# Altium schematic sheet Xface Conn.SCHDOC("Xface Conn")
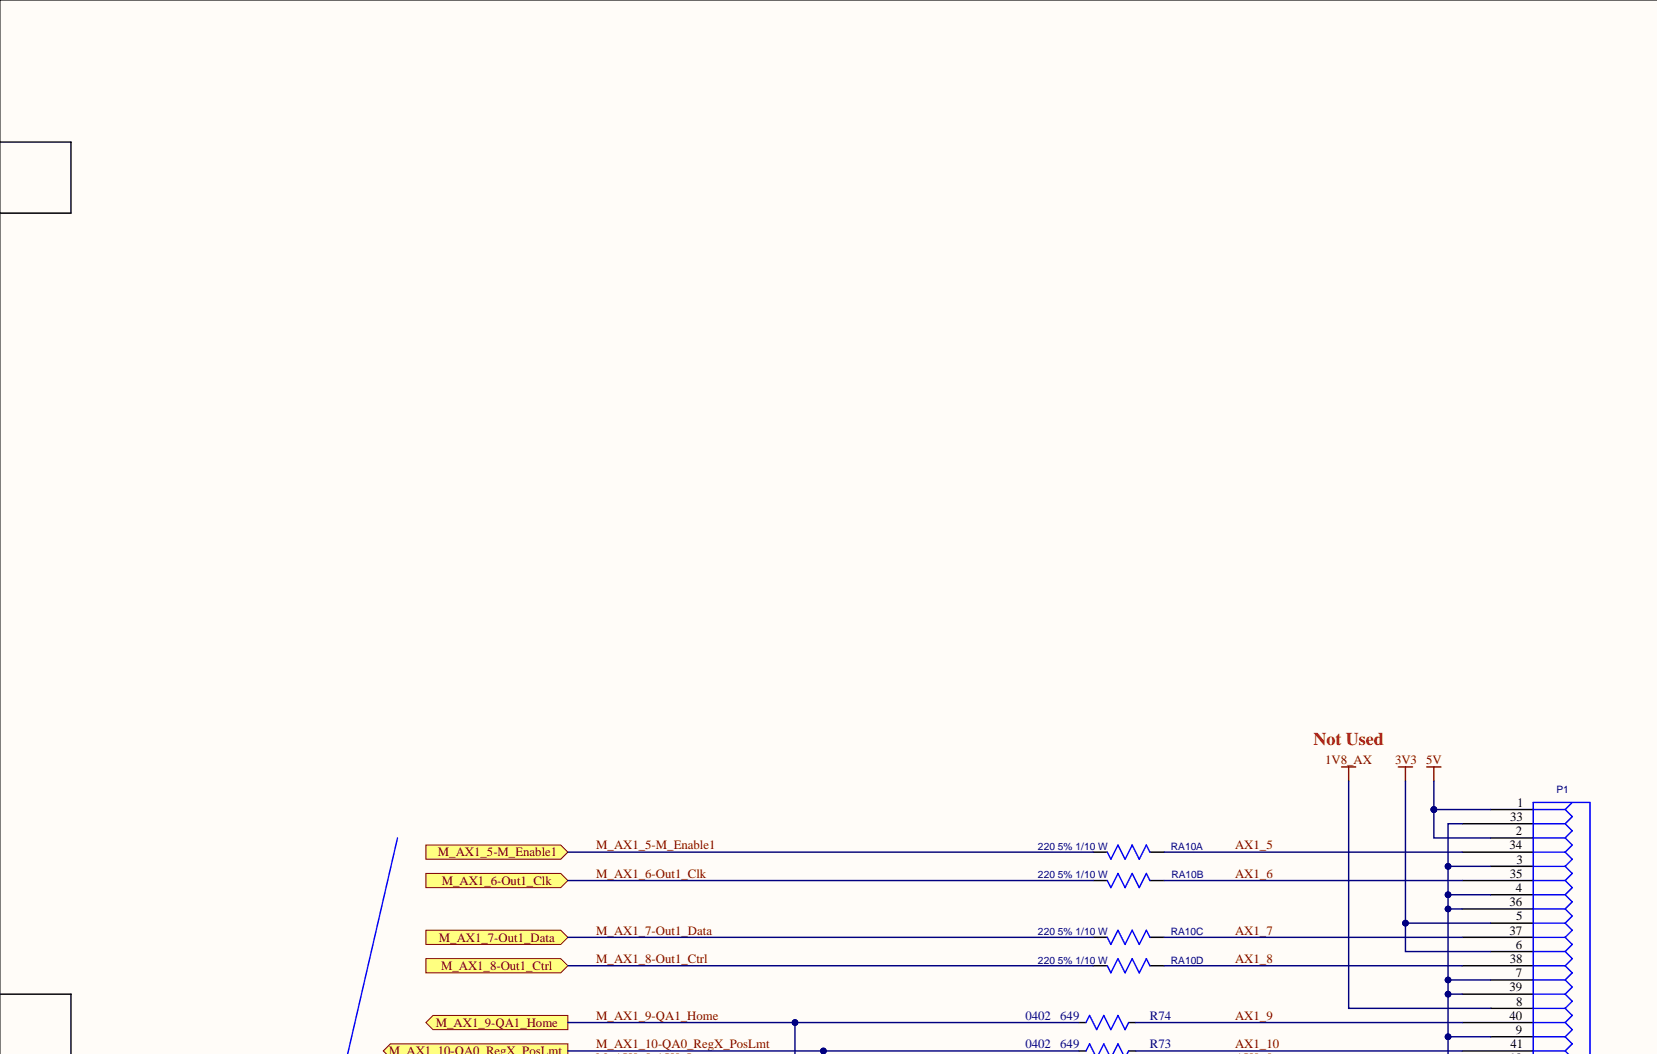
[diagram p0: Xface Conn.SCHDOC, top left]
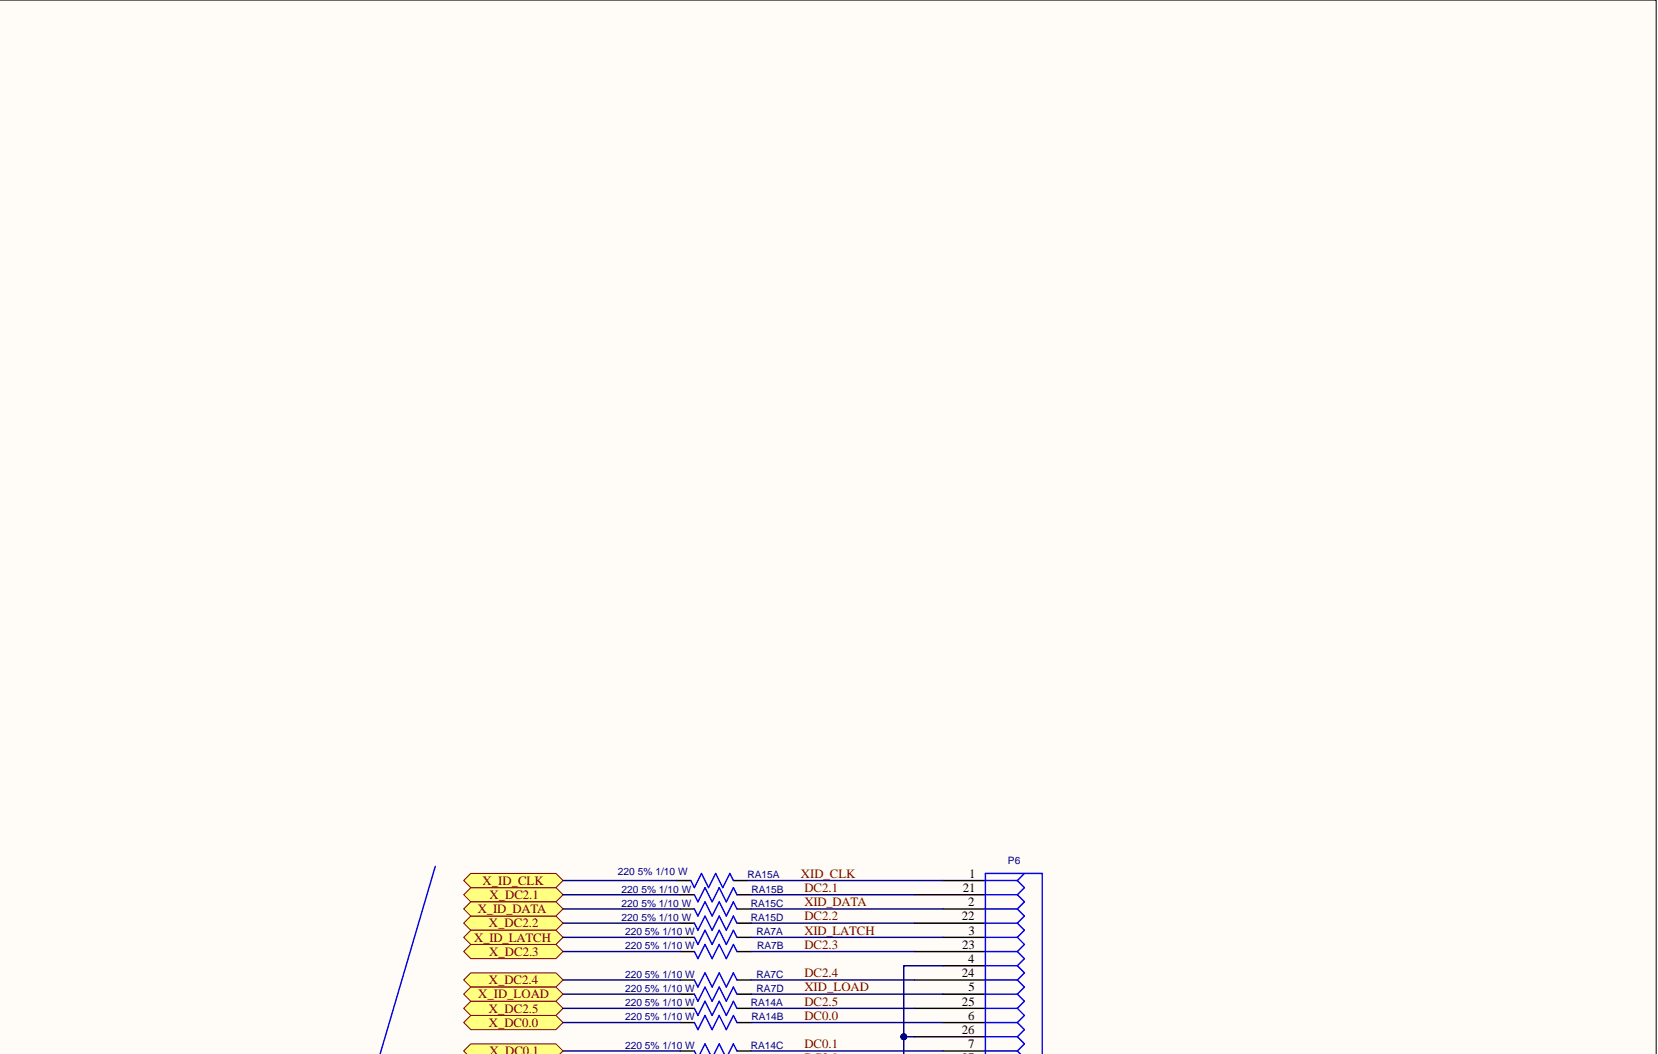
[diagram p1: Xface Conn.SCHDOC, top right]
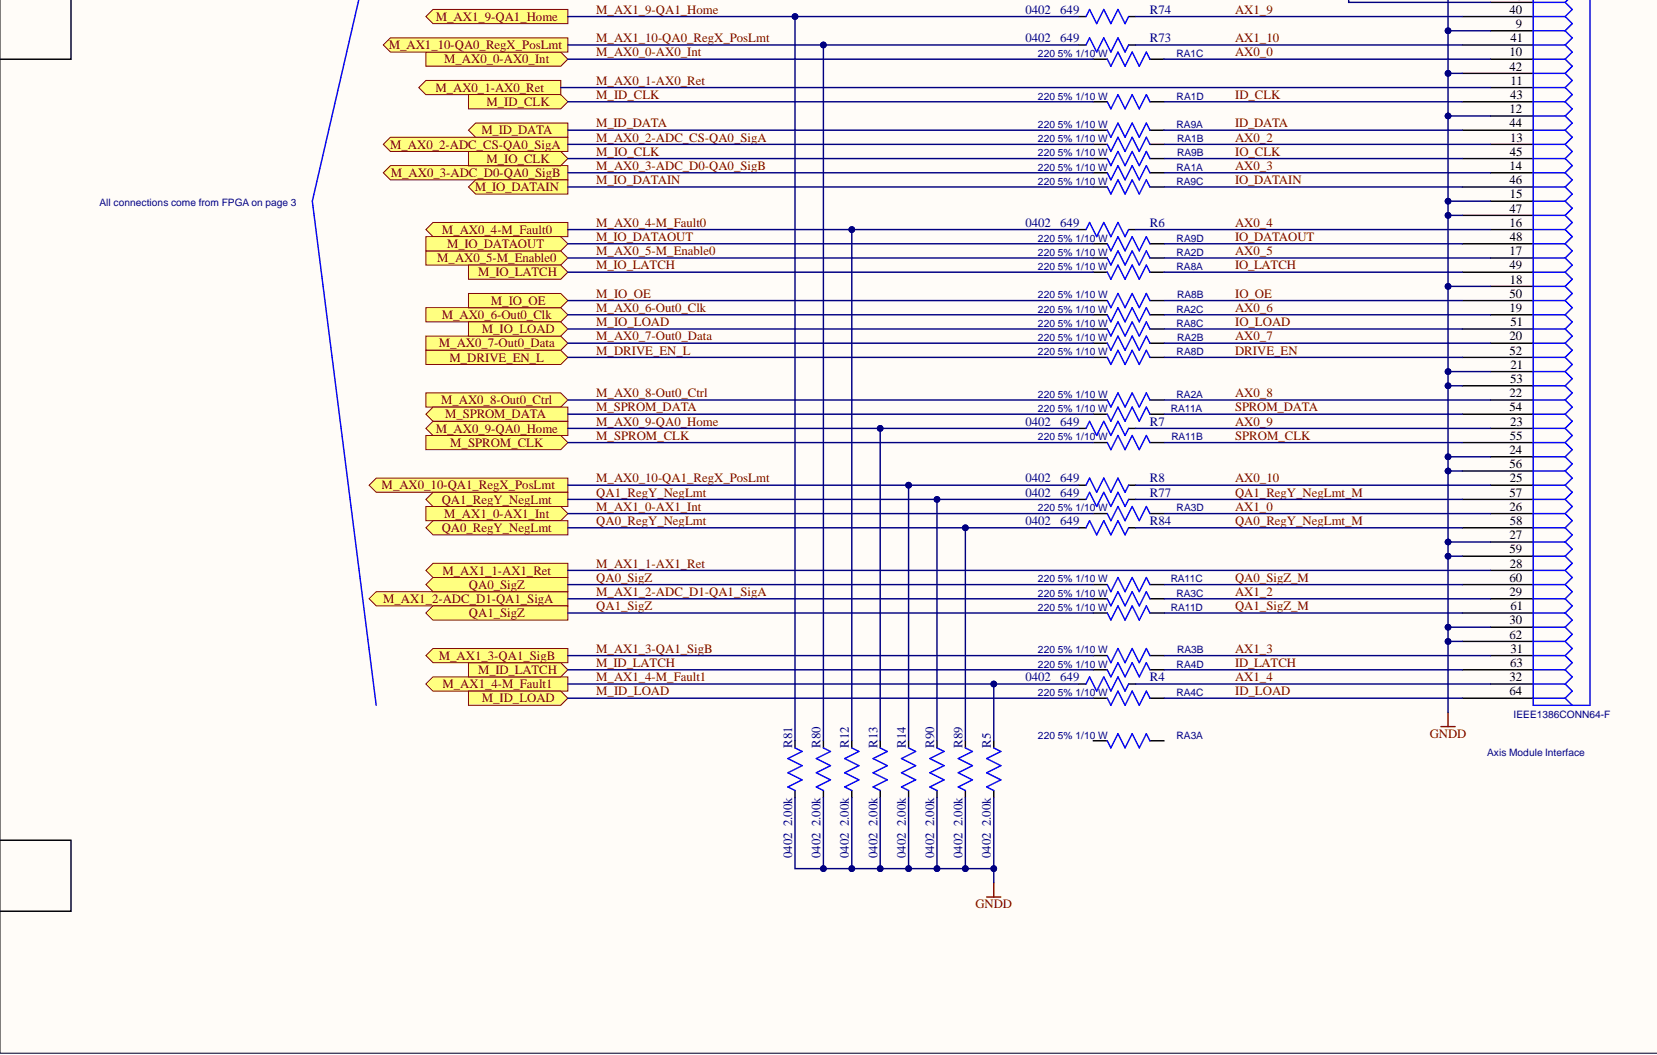
[diagram p2: Xface Conn.SCHDOC, bottom left]
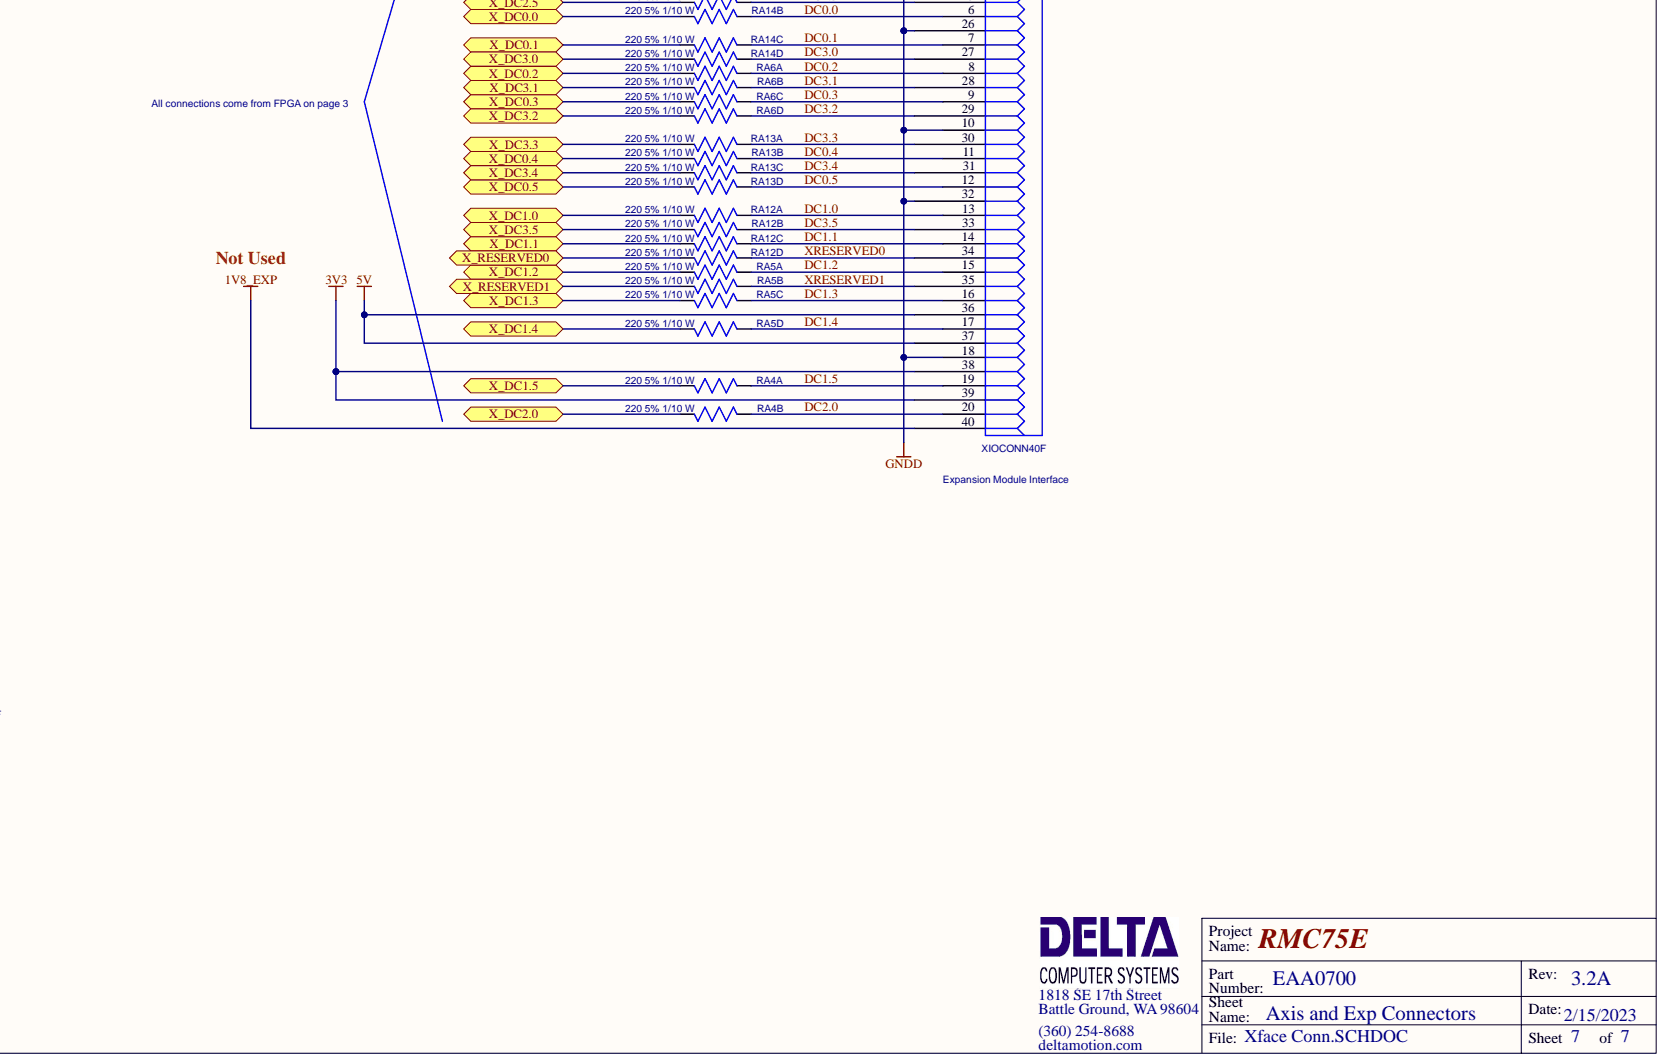
[diagram p3: Xface Conn.SCHDOC, bottom right]

## Parts
P1  IEEE1386CONN64-F  [MEZZCONNIEEE1386-64]
P6  XIOCONN40F  [XIOCONN40F]
R4  649  CaseSize: 0402  [RESC1005-N [0402]]
R5  2.00k  CaseSize: 0402  [RESC1005-N [0402]]
R6  649  CaseSize: 0402  [RESC1005-N [0402]]
R7  649  CaseSize: 0402  [RESC1005-N [0402]]
R8  649  CaseSize: 0402  [RESC1005-N [0402]]
R12  2.00k  CaseSize: 0402  [RESC1005-N [0402]]
R13  2.00k  CaseSize: 0402  [RESC1005-N [0402]]
R14  2.00k  CaseSize: 0402  [RESC1005-N [0402]]
R73  649  CaseSize: 0402  [RESC1005-N [0402]]
R74  649  CaseSize: 0402  [RESC1005-N [0402]]
R77  649  CaseSize: 0402  [RESC1005-N [0402]]
R80  2.00k  CaseSize: 0402  [RESC1005-N [0402]]
R81  2.00k  CaseSize: 0402  [RESC1005-N [0402]]
R84  649  CaseSize: 0402  [RESC1005-N [0402]]
R89  2.00k  CaseSize: 0402  [RESC1005-N [0402]]
R90  2.00k  CaseSize: 0402  [RESC1005-N [0402]]
RA1  220 5% 1/10 W  [RES ARRAY 742]  (parts A,B,C,D)
RA2  220 5% 1/10 W  [RES ARRAY 742]  (parts A,B,C,D)
RA3  220 5% 1/10 W  [RES ARRAY 742]  (parts A,B,C,D)
RA4  220 5% 1/10 W  [RES ARRAY 742]  (parts A,B,C,D)
RA5  220 5% 1/10 W  [RES ARRAY 742]  (parts A,B,C,D)
RA6  220 5% 1/10 W  [RES ARRAY 742]  (parts A,B,C,D)
RA7  220 5% 1/10 W  [RES ARRAY 742]  (parts A,B,C,D)
RA8  220 5% 1/10 W  [RES ARRAY 742]  (parts A,B,C,D)
RA9  220 5% 1/10 W  [RES ARRAY 742]  (parts A,B,C,D)
RA10  220 5% 1/10 W  [RES ARRAY 742]  (parts A,B,C,D)
RA11  220 5% 1/10 W  [RES ARRAY 742]  (parts A,B,C,D)
RA12  220 5% 1/10 W  [RES ARRAY 742]  (parts A,B,C,D)
RA13  220 5% 1/10 W  [RES ARRAY 742]  (parts A,B,C,D)
RA14  220 5% 1/10 W  [RES ARRAY 742]  (parts A,B,C,D)
RA15  220 5% 1/10 W  [RES ARRAY 742]  (parts A,B,C,D)

## Ports
M_AX0_0-AX0_Int, M_AX0_1-AX0_Ret, M_AX0_2-ADC_CS-QA0_SigA, M_AX0_3-ADC_D0-QA0_SigB, M_AX0_4-M_Fault0, M_AX0_5-M_Enable0, M_AX0_6-Out0_Clk, M_AX0_7-Out0_Data, M_AX0_8-Out0_Ctrl, M_AX0_9-QA0_Home, M_AX0_10-QA1_RegX_PosLmt, M_AX1_0-AX1_Int, M_AX1_1-AX1_Ret, M_AX1_2-ADC_D1-QA1_SigA, M_AX1_3-QA1_SigB, M_AX1_4-M_Fault1, M_AX1_5-M_Enable1, M_AX1_6-Out1_Clk, M_AX1_7-Out1_Data, M_AX1_8-Out1_Ctrl, M_AX1_9-QA1_Home, M_AX1_10-QA0_RegX_PosLmt, M_DRIVE_EN_L, M_ID_CLK, M_ID_DATA, M_ID_LATCH, M_ID_LOAD, M_IO_CLK, M_IO_DATAIN, M_IO_DATAOUT, M_IO_LATCH, M_IO_LOAD, M_IO_OE, M_SPROM_CLK, M_SPROM_DATA, QA0_RegY_NegLmt, QA0_SigZ, QA1_RegY_NegLmt, QA1_SigZ, X_DC0.0, X_DC0.1, X_DC0.2, X_DC0.3, X_DC0.4, X_DC0.5, X_DC1.0, X_DC1.1, X_DC1.2, X_DC1.3, X_DC1.4, X_DC1.5, X_DC2.0, X_DC2.1, X_DC2.2, X_DC2.3, X_DC2.4, X_DC2.5, X_DC3.0, X_DC3.1, X_DC3.2, X_DC3.3, X_DC3.4, X_DC3.5, X_ID_CLK, X_ID_DATA, X_ID_LATCH, X_ID_LOAD, X_RESERVED0, X_RESERVED1

## Nets
1V8_AX: P1.8
1V8_EXP: P6.40
3V3: P1.5 P1.6 P6.38 P6.39
5V: P1.1 P1.2 P6.36 P6.37
GNDD: P1.3 P1.4 P1.7 P1.9 P1.12 P1.15 P1.18 P1.21 P1.24 P1.27 P1.30 P1.33 P1.36 P1.39 P1.42 P1.47 P1.53 P1.56 P1.59 P1.62 P6.4 P6.10 P6.18 P6.26 P6.32 R5.1 R12.1 R13.1 R14.1 R80.1 R81.1 R89.1 R90.1
AX0_0: P1.10 RA1.3
AX0_2: P1.13 RA1.2
AX0_3: P1.14 RA1.1
AX0_4: P1.16 R6.2
AX0_5: P1.17 RA2.4
AX0_6: P1.19 RA2.3
AX0_7: P1.20 RA2.2
AX0_8: P1.22 RA2.1
AX0_9: P1.23 R7.2
AX0_10: P1.25 R8.2
AX1_0: P1.26 RA3.4
AX1_2: P1.29 RA3.3
AX1_3: P1.31 RA3.2
AX1_4: P1.32 R4.2
AX1_5: P1.34 RA10.1
AX1_6: P1.35 RA10.2
AX1_7: P1.37 RA10.3
AX1_8: P1.38 RA10.4
AX1_9: P1.40 R74.2
AX1_10: P1.41 R73.2
DC0.0: P6.6 RA14.7
DC0.1: P6.7 RA14.6
DC0.2: P6.8 RA6.1
DC0.3: P6.9 RA6.3
DC0.4: P6.11 RA13.7
DC0.5: P6.12 RA13.5
DC1.0: P6.13 RA12.8
DC1.1: P6.14 RA12.6
DC1.2: P6.15 RA5.1
DC1.3: P6.16 RA5.3
DC1.4: P6.17 RA5.4
DC1.5: P6.19 RA4.1
DC2.0: P6.20 RA4.2
DC2.1: P6.21 RA15.7
DC2.2: P6.22 RA15.5
DC2.3: P6.23 RA7.2
DC2.4: P6.24 RA7.3
DC2.5: P6.25 RA14.8
DC3.0: P6.27 RA14.5
DC3.1: P6.28 RA6.2
DC3.2: P6.29 RA6.4
DC3.3: P6.30 RA13.8
DC3.4: P6.31 RA13.6
DC3.5: P6.33 RA12.7
DRIVE_EN: P1.52 RA8.4
ID_CLK: P1.43 RA1.4
ID_DATA: P1.44 RA9.1
ID_LATCH: P1.63 RA4.4
ID_LOAD: P1.64 RA4.3
IO_CLK: P1.45 RA9.2
IO_DATAIN: P1.46 RA9.3
IO_DATAOUT: P1.48 RA9.4
IO_LATCH: P1.49 RA8.1
IO_LOAD: P1.51 RA8.3
IO_OE: P1.50 RA8.2
M_AX0_0-AX0_Int: RA1.6 ; port M_AX0_0-AX0_Int
M_AX0_1-AX0_Ret: P1.11 ; port M_AX0_1-AX0_Ret
M_AX0_2-ADC_CS-QA0_SigA: RA1.7 ; port M_AX0_2-ADC_CS-QA0_SigA
M_AX0_3-ADC_D0-QA0_SigB: RA1.8 ; port M_AX0_3-ADC_D0-QA0_SigB
M_AX0_4-M_Fault0: R6.1 R12.2 ; port M_AX0_4-M_Fault0
M_AX0_5-M_Enable0: RA2.5 ; port M_AX0_5-M_Enable0
M_AX0_6-Out0_Clk: RA2.6 ; port M_AX0_6-Out0_Clk
M_AX0_7-Out0_Data: RA2.7 ; port M_AX0_7-Out0_Data
M_AX0_8-Out0_Ctrl: RA2.8 ; port M_AX0_8-Out0_Ctrl
M_AX0_9-QA0_Home: R7.1 R13.2 ; port M_AX0_9-QA0_Home
M_AX0_10-QA1_RegX_PosLmt: R8.1 R14.2 ; port M_AX0_10-QA1_RegX_PosLmt
M_AX1_0-AX1_Int: RA3.5 ; port M_AX1_0-AX1_Int
M_AX1_1-AX1_Ret: P1.28 ; port M_AX1_1-AX1_Ret
M_AX1_2-ADC_D1-QA1_SigA: RA3.6 ; port M_AX1_2-ADC_D1-QA1_SigA
M_AX1_3-QA1_SigB: RA3.7 ; port M_AX1_3-QA1_SigB
M_AX1_4-M_Fault1: R4.1 R5.2 ; port M_AX1_4-M_Fault1
M_AX1_5-M_Enable1: RA10.8 ; port M_AX1_5-M_Enable1
M_AX1_6-Out1_Clk: RA10.7 ; port M_AX1_6-Out1_Clk
M_AX1_7-Out1_Data: RA10.6 ; port M_AX1_7-Out1_Data
M_AX1_8-Out1_Ctrl: RA10.5 ; port M_AX1_8-Out1_Ctrl
M_AX1_9-QA1_Home: R74.1 R81.2 ; port M_AX1_9-QA1_Home
M_AX1_10-QA0_RegX_PosLmt: R73.1 R80.2 ; port M_AX1_10-QA0_RegX_PosLmt
M_DRIVE_EN_L: RA8.5 ; port M_DRIVE_EN_L
M_ID_CLK: RA1.5 ; port M_ID_CLK
M_ID_DATA: RA9.8 ; port M_ID_DATA
M_ID_LATCH: RA4.5 ; port M_ID_LATCH
M_ID_LOAD: RA4.6 ; port M_ID_LOAD
M_IO_CLK: RA9.7 ; port M_IO_CLK
M_IO_DATAIN: RA9.6 ; port M_IO_DATAIN
M_IO_DATAOUT: RA9.5 ; port M_IO_DATAOUT
M_IO_LATCH: RA8.8 ; port M_IO_LATCH
M_IO_LOAD: RA8.6 ; port M_IO_LOAD
M_IO_OE: RA8.7 ; port M_IO_OE
M_SPROM_CLK: RA11.7 ; port M_SPROM_CLK
M_SPROM_DATA: RA11.8 ; port M_SPROM_DATA
QA0_RegY_NegLmt: R84.1 R89.2 ; port QA0_RegY_NegLmt
QA0_RegY_NegLmt_M: P1.58 R84.2
QA0_SigZ: RA11.6 ; port QA0_SigZ
QA0_SigZ_M: P1.60 RA11.3
QA1_RegY_NegLmt: R77.1 R90.2 ; port QA1_RegY_NegLmt
QA1_RegY_NegLmt_M: P1.57 R77.2
QA1_SigZ: RA11.5 ; port QA1_SigZ
QA1_SigZ_M: P1.61 RA11.4
SPROM_CLK: P1.55 RA11.2
SPROM_DATA: P1.54 RA11.1
X_DC0.0: RA14.2 ; port X_DC0.0
X_DC0.1: RA14.3 ; port X_DC0.1
X_DC0.2: RA6.8 ; port X_DC0.2
X_DC0.3: RA6.6 ; port X_DC0.3
X_DC0.4: RA13.2 ; port X_DC0.4
X_DC0.5: RA13.4 ; port X_DC0.5
X_DC1.0: RA12.1 ; port X_DC1.0
X_DC1.1: RA12.3 ; port X_DC1.1
X_DC1.2: RA5.8 ; port X_DC1.2
X_DC1.3: RA5.6 ; port X_DC1.3
X_DC1.4: RA5.5 ; port X_DC1.4
X_DC1.5: RA4.8 ; port X_DC1.5
X_DC2.0: RA4.7 ; port X_DC2.0
X_DC2.1: RA15.2 ; port X_DC2.1
X_DC2.2: RA15.4 ; port X_DC2.2
X_DC2.3: RA7.7 ; port X_DC2.3
X_DC2.4: RA7.6 ; port X_DC2.4
X_DC2.5: RA14.1 ; port X_DC2.5
X_DC3.0: RA14.4 ; port X_DC3.0
X_DC3.1: RA6.7 ; port X_DC3.1
X_DC3.2: RA6.5 ; port X_DC3.2
X_DC3.3: RA13.1 ; port X_DC3.3
X_DC3.4: RA13.3 ; port X_DC3.4
X_DC3.5: RA12.2 ; port X_DC3.5
X_ID_CLK: RA15.1 ; port X_ID_CLK
X_ID_DATA: RA15.3 ; port X_ID_DATA
X_ID_LATCH: RA7.8 ; port X_ID_LATCH
X_ID_LOAD: RA7.5 ; port X_ID_LOAD
X_RESERVED0: RA12.4 ; port X_RESERVED0
X_RESERVED1: RA5.7 ; port X_RESERVED1
XID_CLK: P6.1 RA15.8
XID_DATA: P6.2 RA15.6
XID_LATCH: P6.3 RA7.1
XID_LOAD: P6.5 RA7.4
XRESERVED0: P6.34 RA12.5
XRESERVED1: P6.35 RA5.2

Not connected: RA3 1 8

## Library data of the parts
P1 = IEEE1386CONN64-F: Conn Recpt 1mm 64p (2x32) ST; Manufacturer: Molex; PartNumber: 71439-0164; Component Kind: Standard
P6 = XIOCONN40F: Conn Plug Assy 40p R/A; Manufacturer: TE; PartNumber: 1734099-4; Component Kind: Standard
R4 R6-R8 R73 R74 R77 R84 = Resistor: Res 649k 1% 1/10W 0402; Manufacturer: Venkel; ManufacturerAlt1: Various; PartNumber: CR0402-10W-6490FT; Tolerance: 1.0%; Component Kind: Standard; ComponentType: Resistor; DateUpdated: 04/26/2022; Library Name: Resistor.IntLib; PartNumberAlt1: Various; PowerRating: 1/10W; Value: 649
R5 R12-R14 R80 R81 R89 R90 = Resistor: Res 2k 1% 1/10W 0402; Manufacturer: Venkel; ManufacturerAlt1: Various; PartNumber: CR0402-10W-2001FT; Tolerance: 1.0%; Component Kind: Standard; ComponentType: Resistor; DateUpdated: 04/26/2022; Library Name: Resistor.IntLib; PartNumberAlt1: Various; PowerRating: 1/10W; Value: 2.00k
RA1-RA15 = R-ARRAY 0603 X 4: Res Array 220 5% 1/16W 4x0603; Manufacturer: Venkel; PartNumber: CRN16-4-221JT; Component Kind: Standard; Value: 220 5% 1/10 W
